# Altium schematic sheet PWR_FPGA.SchDoc(PWR_FPGA)
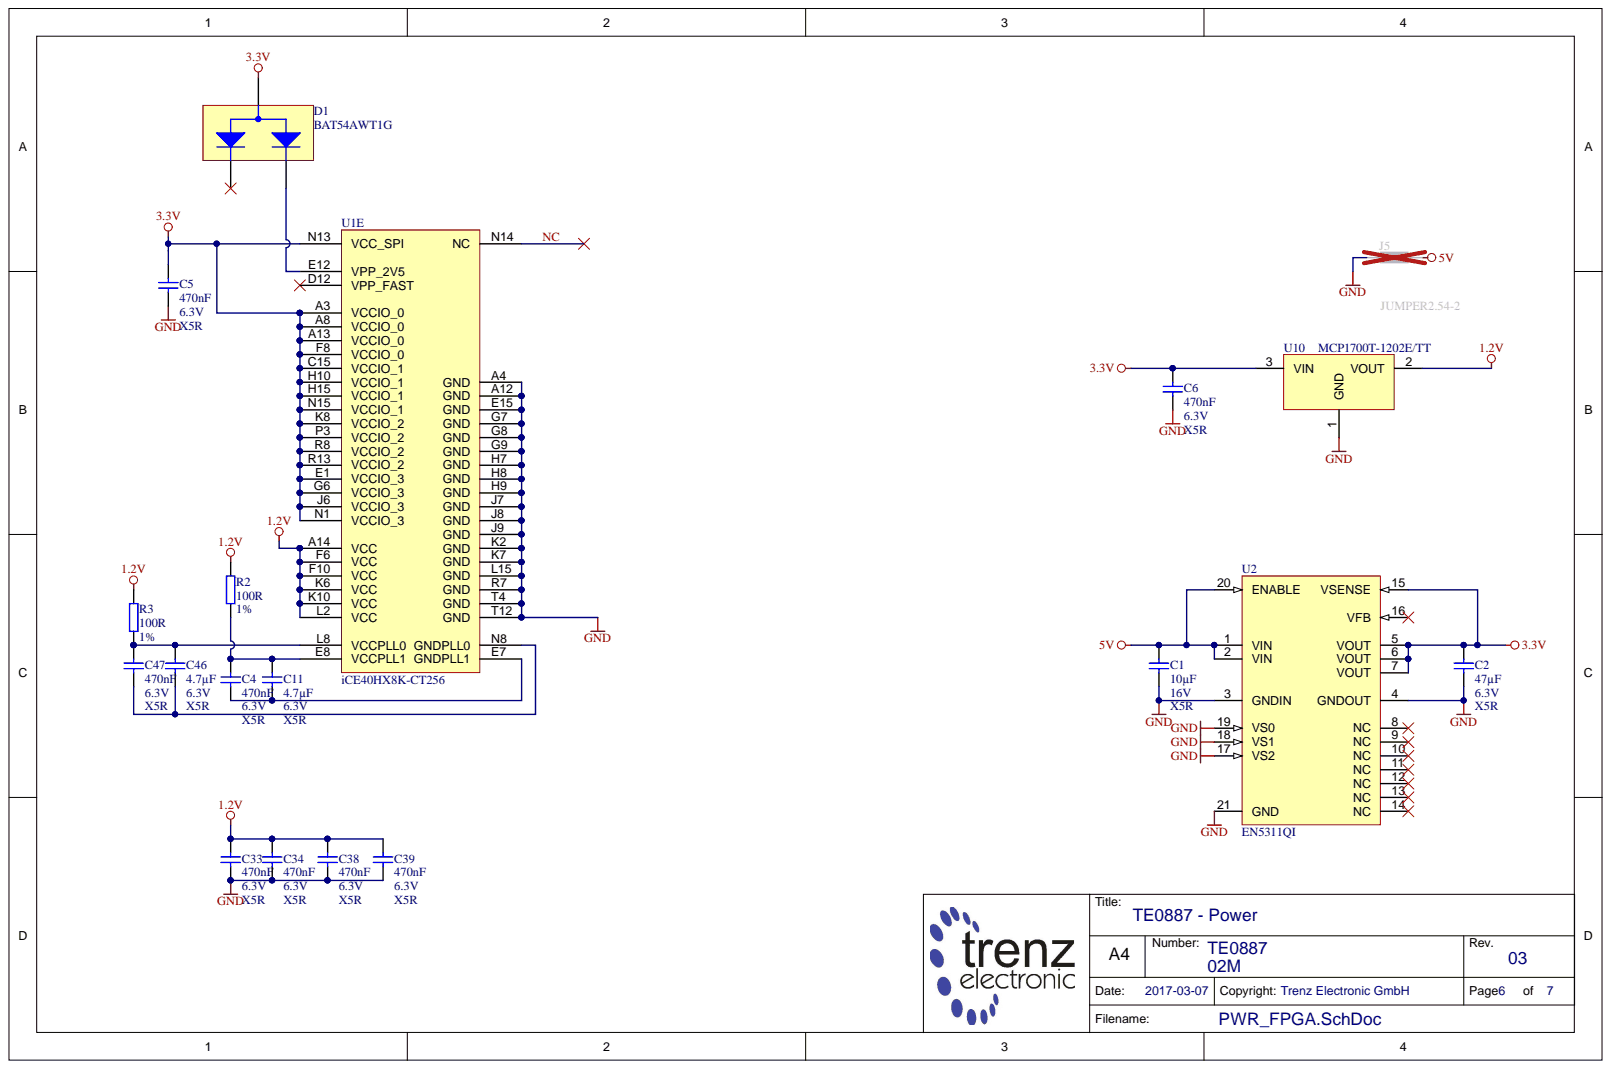
[diagram p0: PWR_FPGA.SchDoc]

## Parts
C1  10µF  Technology: X5R  Voltage: 16V  [CAPC1608X90N]
C2  47µF  Technology: X5R  Voltage: 6.3V  [CAPC2012X135N]
C4  470nF  Technology: X5R  Voltage: 6.3V  [CAPC0603X33N]
C5  470nF  Technology: X5R  Voltage: 6.3V  [CAPC0603X33N]
C6  470nF  Technology: X5R  Voltage: 6.3V  [CAPC0603X33N]
C11  4.7µF  Technology: X5R  Voltage: 6.3V  [CAPC1005X60N]
C33  470nF  Technology: X5R  Voltage: 6.3V  [CAPC0603X33N]
C34  470nF  Technology: X5R  Voltage: 6.3V  [CAPC0603X33N]
C38  470nF  Technology: X5R  Voltage: 6.3V  [CAPC0603X33N]
C39  470nF  Technology: X5R  Voltage: 6.3V  [CAPC0603X33N]
C46  4.7µF  Technology: X5R  Voltage: 6.3V  [CAPC1005X60N]
C47  470nF  Technology: X5R  Voltage: 6.3V  [CAPC0603X33N]
D1  BAT54AWT1G  [SOT65P210X110-3N]
J5  JUMPER2.54-2  [JUMPER2_54-2]
R2  100R  Tolerance: 1%  [RESC1005X40N]
R3  100R  Tolerance: 1%  [RESC1005X40N]
U1  iCE40HX8K-CT256  [BGA256C80_1400X1400X170B45]  (part E)
U2  EN5311QI  [EN5311QI]
U10  MCP1700T-1202E/TT  [SOT95P237X112-3N]

## Nets
1.2V: C33.1 C34.1 C38.1 C39.1 R2.1 R3.1 U1.A14(VCC) U1.F6(VCC) U1.F10(VCC) U1.K6(VCC) U1.K10(VCC) U1.L2(VCC) U10.2
3.3V: C2.1 C5.1 C6.2 D1.3 U1.A3(VCCIO_0) U1.A8(VCCIO_0) U1.A13(VCCIO_0) U1.C15(VCCIO_1) U1.E1(VCCIO_3) U1.F8(VCCIO_0) U1.G6(VCCIO_3) U1.H10(VCCIO_1) U1.H15(VCCIO_1) U1.J6(VCCIO_3) U1.K8(VCCIO_2) U1.N1(VCCIO_3) U1.N13(VCC_SPI) U1.N15(VCCIO_1) U1.P3(VCCIO_2) U1.R8(VCCIO_2) U1.R13(VCCIO_2) U2.5(VOUT) U2.6(VOUT) U2.7(VOUT) U2.15(VSENSE) U10.3
5V: C1.1 J5.2 U2.1(VIN) U2.2(VIN) U2.20(ENABLE)
GND: C1.2 C2.2 C5.2 C6.1 C33.2 C34.2 C38.2 C39.2 J5.1 U1.A4(GND) U1.A12(GND) U1.E15(GND) U1.G7(GND) U1.G8(GND) U1.G9(GND) U1.H7(GND) U1.H8(GND) U1.H9(GND) U1.J7(GND) U1.J8(GND) U1.J9(GND) U1.K2(GND) U1.K7(GND) U1.L15(GND) U1.R7(GND) U1.T4(GND) U1.T12(GND) U2.3(GNDIN) U2.4(GNDOUT) U2.17(VS2) U2.18(VS1) U2.19(VS0) U2.21(GND) U10.1
NC: U1.N14(NC)
NetC4_1: C4.1 C11.1 R2.2 U1.E8(VCCPLL1)
NetC4_2: C4.2 C11.2 U1.E7(GNDPLL1)
NetC46_1: C46.1 C47.1 R3.2 U1.L8(VCCPLL0)
NetC46_2: C46.2 C47.2 U1.N8(GNDPLL0)
NetD1_2: D1.2 U1.E12(VPP_2V5)

Not connected: D1 1; U1 D12(VPP_FAST); U2 8(NC) 9(NC) 10(NC) 11(NC) 12(NC) 13(NC) 14(NC) 16(VFB)

## Library data of the parts
C1 = 25341: KON 10µF 16V X5R SMD 20%; Database Table Name: C_1608_0603; Mixed: SMT; Preferred: Yes; Value: 10u; AN: 25341; Case: 1608 (0603); Component Kind: Standard; Library Name: TE.DbLib; Tolerance: 20%
C2 = 24718: KON 47µF 6,3V  X5R SMD 20%; Database Table Name: C_2012_0805; Mixed: SMT; Preferred: Yes; Value: 47u; AN: 24718; Case: 2012 (0805); Component Kind: Standard; Library Name: TE.DbLib; Tolerance: 20%
C4-C6 C33 C34 C38 C39 C47 = 24921: KON 470nF X5R 6.3V SMD 20%; Database Table Name: C_0603_0201; Mixed: SMT; Preferred: Yes; Value: 470n; AN: 24921; Case: 0603 (0201); Component Kind: Standard; Library Name: TE.DbLib; Tolerance: 20%
C11 C46 = 24719: KON 4.7µF 6.3V X5R SMD 20%; Database Table Name: C_1005_0402; Mixed: SMT; Preferred: Yes; Value: 4.7u; AN: 24719; Case: 1005 (0402); Component Kind: Standard; Library Name: TE.DbLib; Tolerance: 20%
D1 = 26805: Database Table Name: DIODE; Mixed: SMT; AN: 26805; Case: SOT323; Component Kind: Standard; Description: BAT54AWT1G; H-ART: BAT54AWT1G; Library Name: TE.DbLib; HelpURL: B:\ad\adlib\datasheet\BAT54AW
J5 = 22330: Stiftleiste gerade 1-reihig, 2pol. (Jumper); Database Table Name: CON_HEADER; Mixed: THT; Trenz-Stock: Yes; AN: 22330; Component Kind: Standard; Library Name: TE.DbLib
R2 R3 = 22507: WID SMD 100R 1% 0,063W; Database Table Name: R_1005_0402; Mixed: SMT; Preferred: Yes; Value: 100; AN: 22507; Case: 1005 (0402); Component Kind: Standard; Library Name: TE.DbLib; Technology: TK100
U1 = 27167: Database Table Name: PROG_LOGIC; Mixed: SMT; AN: 27167; Case: CT256; Component Kind: Standard; Description: iCE40HX8K-CT256; H-ART: iCE40HX8K-CT256; Library Name: TE.DbLib; HelpURL: B:\AD\adlib\datasheet\ICE40
U2 = 25492: Database Table Name: DCDC; Mixed: SMT; Preferred: Yes; AN: 25492; Case: QFN20; Component Kind: Standard; Description: EN5311QI; H-ART: EN5311QI; Library Name: TE.DbLib; Trenz-Stock: Yes; HelpURL: B:\AD\adlib\datasheet\EN5311QI
U10 = 26755: Database Table Name: LREG; Mixed: SMT; Trenz-Stock: Yes; AN: 26755; Case: SOT23-3; Component Kind: Standard; Description: MCP1700T-1202E/TT; H-ART: MCP1700T-1202E/TT; Library Name: TE.DbLib; HelpURL: B:\ad\adlib\datasheet\MCP1700
